# Altium schematic sheet Offset_Selector.SchDoc(Offset_selector1)
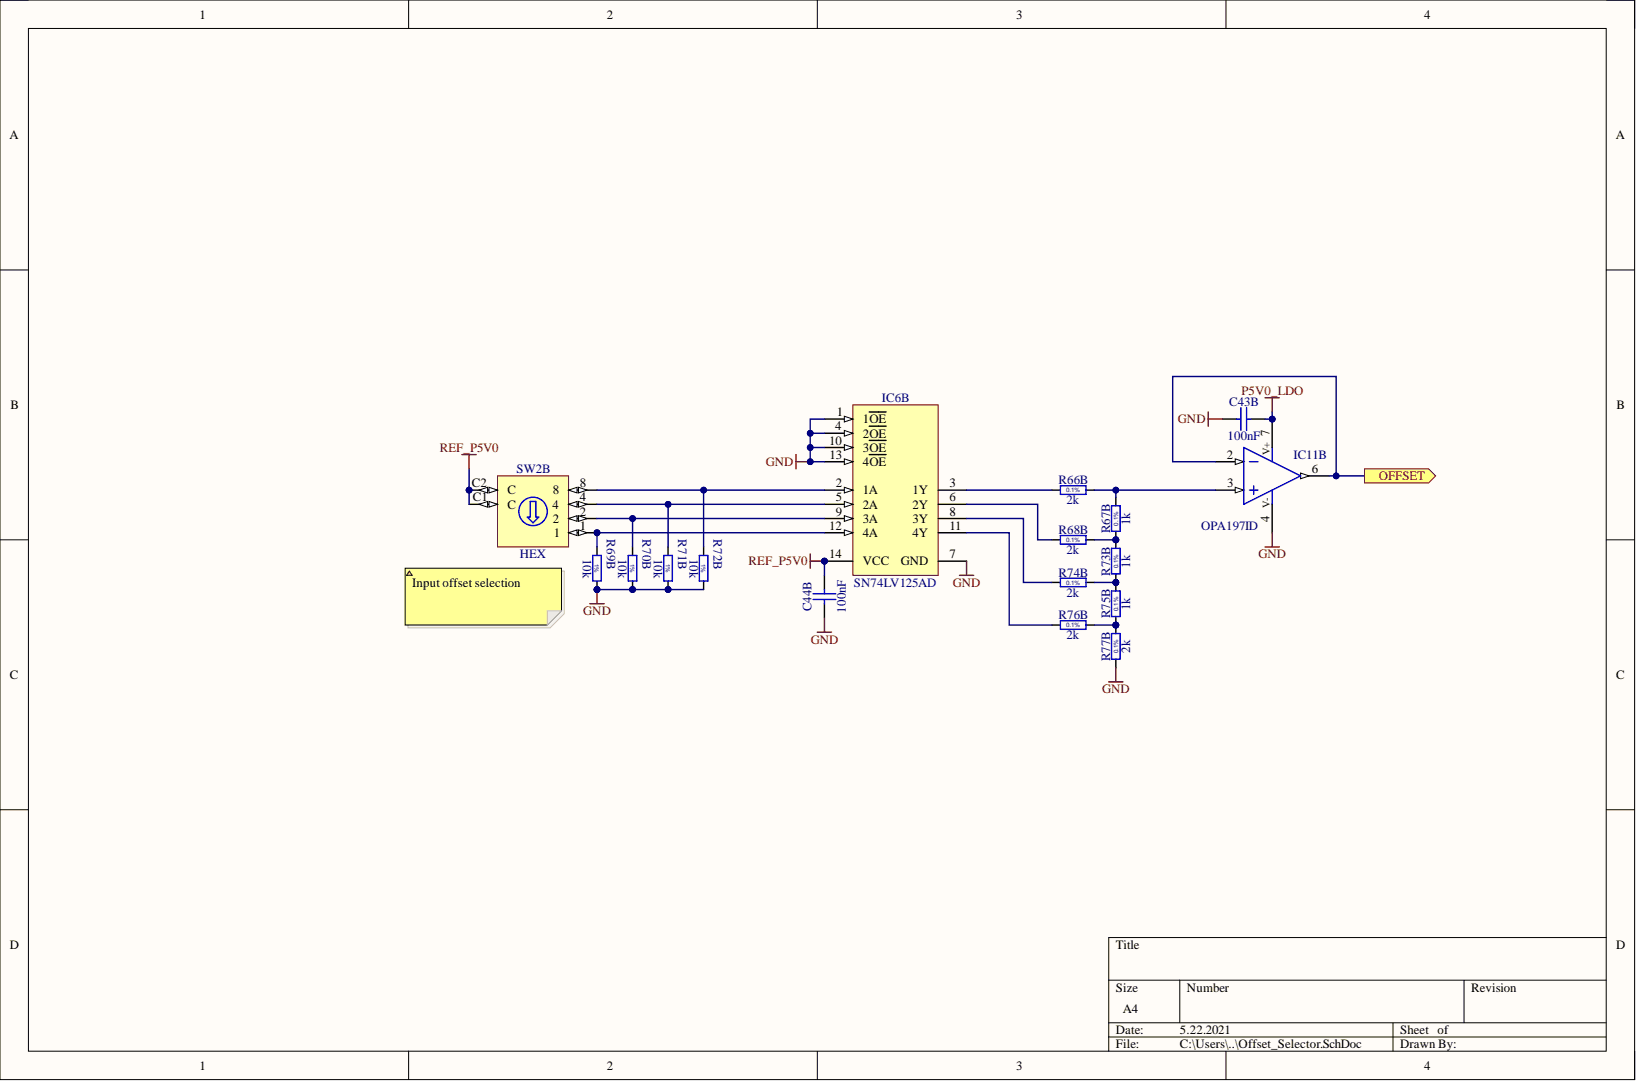
[diagram p0: Offset_Selector.SchDoc]

## Parts
C43B  100nF  [CAPC1608X87N]
C44B  100nF  [CAPC1608X87N]
IC6B  SN74LV125AD  [SOIC127P600X175-14N]
IC11B  OPA197ID  [SOIC127P600X175-8N]
R66B  2k  [RESC1608X55N]
R67B  1k  [RESC1608X55N]
R68B  2k  [RESC1608X55N]
R69B  10k  [RESC1608X55N]
R70B  10k  [RESC1608X55N]
R71B  10k  [RESC1608X55N]
R72B  10k  [RESC1608X55N]
R73B  1k  [RESC1608X55N]
R74B  2k  [RESC1608X55N]
R75B  1k  [RESC1608X55N]
R76B  2k  [RESC1608X55N]
R77B  2k  [RESC1608X55N]
SW2B  HEX  [SW_APEM_PT65106L254]

## Ports
OFFSETB

## Nets
GND: C43B.1 C44B.1 IC6B.1(1OE) IC6B.4(2OE) IC6B.7(GND) IC6B.10(3OE) IC6B.13(4OE) IC11B.4 R69B.2 R70B.2 R71B.2 R72B.2 R77B.1
P5V0_LDO: C43B.2 IC11B.7
REF_P5V0: C44B.2 IC6B.14(VCC) SW2B.C1(C) SW2B.C2(C)
NetIC6B_2: IC6B.2(1A) R72B.1 SW2B.8
NetIC6B_3: IC6B.3(1Y) R66B.1
NetIC6B_5: IC6B.5(2A) R71B.1 SW2B.4
NetIC6B_6: IC6B.6(2Y) R68B.1
NetIC6B_8: IC6B.8(3Y) R74B.1
NetIC6B_9: IC6B.9(3A) R70B.1 SW2B.2
NetIC6B_11: IC6B.11(4Y) R76B.1
NetIC6B_12: IC6B.12(4A) R69B.1 SW2B.1
NetIC11B_2: IC11B.2 IC11B.6
NetIC11B_3: IC11B.3 R66B.2 R67B.2
NetR67B_1: R67B.1 R68B.2 R73B.2
NetR73B_1: R73B.1 R74B.2 R75B.2
NetR75B_1: R75B.1 R76B.2 R77B.2

## Library data of the parts
C43B C44B = CC0603_100NF_50V_10%_X7R: Non-polarised capacitor; Manufacturer: GENERIC; Manufacturer1 Part Number: 223858615649; Part Description: SMD Multilayer Chip Ceramic Capacitor; Database Table Name: Capacitors SMD; TC: X7R; Tolerance: ±10%; Voltage: 50V; Case: 0603; Component Kind: Standard; Component Type: Standard; CreateDate: 03/12/2007; HelpURL: \\cern.ch\dfs\Applications\Altium\Datasheets\CC0603_X7R_PHYCOMP.pdf; LatestRevisionDate: 03/12/2007; Library Name: Capacitors.DbLib; Manufacturer1 ComponentHeight: 0.87mm; Manufacturer1 Example: PHYCOMP; Manufacturer Part Number: CC0603_100NF_50V_10%_X7R; Mounted: Yes; Part Number: CC0603_100NF_50V_10%_X7R; Pin Count: 2; Sense: No; SMD: Yes; Socket: No; Status: Preferred; Value: 100nF; Author: CERN DEM JLC
IC6B = SN74LV125AD: Quadruple Bus Buffer with 3-State Outputs; Manufacturer: TEXAS INSTRUMENTS; Bonding: No; Database Table Name: Standard Logic; PressFit: No; Case: SOIC14; Component Kind: Standard; Component Type: Standard; ComponentHeight: 1.75mm; CreateDate: 09.03.2011; Device: SN74LV125AD; HelpURL: \\cern.ch\dfs\Applications\Altium\Datasheets\SN74LV125AD.pdf; LatestRevisionDate: 09.03.2011; Library Name: ICs And Semiconductors.DbLib; Manufacturer Part Number: SN74LV125AD; Mounted: Yes; PackageDescription: SOIC 14, Pitch 1.27mm - Body 4x8.75mm, IPC Medium Density; Part Description: Quadruple Bus Buffer with 3-State Outputs; Part Number: SN74LV125AD; Pin Count: 14; Sense: No; SMD: Yes; Socket: No; Author: CERN DEM JLC
IC11B = OPA197ID: Operational Amplifier; Manufacturer: TEXAS INSTRUMENTS; Part Description: 36-V, Precision, Rail-to-Rail Input/Output, Low Offset Voltage, Operational Amplifiers; Bonding: No; Database Table Name: Operational Amplifiers; PressFit: No; Case: SOIC8; Component Kind: Standard; Component Type: Standard; ComponentHeight: 1.75mm; CreateDate: 30/06/2017; Device: OPA197ID; HelpURL: \\cern.ch\dfs\Applications\Altium\Datasheets\OPA197ID.pdf; LatestRevisionDate: 30/06/2017; Library Name: ICs And Semiconductors.DbLib; Manufacturer Part Number: OPA197ID; Mounted: Yes; PackageDescription: SOIC 8, Pitch 1.27mm - Body 4x5mm, IPC Medium Density; Part Number: OPA197ID; Pin Count: 8; Sense: No; SMD: Yes; Socket: No; Author: CERN DEM MR
R66B R68B R74B R76B R77B = R0603_2K_0.1%_0.063W_10PPM: Resistor - 0.1%; Manufacturer: GENERIC; Manufacturer1 Part Number: RN73C1J2K0BTDF; Part Description: Thin Film Chip Resistor; Database Table Name: Resistors SMD; Power: 0.063W; PressFit: No; TC: ±10ppm; Case: 0603; Component Kind: Standard; Component Type: Standard; CreateDate: 03.12.2007; HelpURL: \\cern.ch\dfs\Applications\Altium\Datasheets\R0603_Tyco_RN73.pdf; LatestRevisionDate: 13.03.2008; Library Name: Resistors.DbLib; Manufacturer1 ComponentHeight: 0.5mm; Manufacturer1 Example: TYCO HOLSWORTHY; Manufacturer Part Number: R0603_2K_0.1%_0.063W_10PPM; Mounted: Yes; Part Number: R0603_2K_0.1%_0.063W_10PPM; Pin Count: 2; Sense: No; SMD: Yes; Socket: No; Status: Not Recommended; Tolerance: ±0.1%; Value: 2k; Author: CERN DEM JLC
R67B R73B R75B = R0603_1K0_0.1%_0.063W_10PPM: Resistor - 0.1%; Manufacturer: GENERIC; Manufacturer1 Part Number: RN73C1J1K0BTDF; Part Description: Thin Film Chip Resistor; Database Table Name: Resistors SMD; Power: 0.063W; PressFit: No; TC: ±10ppm; Case: 0603; Component Kind: Standard; Component Type: Standard; CreateDate: 03.12.2007; HelpURL: \\cern.ch\dfs\Applications\Altium\Datasheets\R0603_Tyco_RN73.pdf; LatestRevisionDate: 13.03.2008; Library Name: Resistors.DbLib; Manufacturer1 ComponentHeight: 0.5mm; Manufacturer1 Example: TYCO HOLSWORTHY; Manufacturer Part Number: R0603_1K0_0.1%_0.063W_10PPM; Mounted: Yes; Part Number: R0603_1K0_0.1%_0.063W_10PPM; Pin Count: 2; Sense: No; SMD: Yes; Socket: No; Status: Not Recommended; Tolerance: ±0.1%; Value: 1k; Author: CERN DEM JLC
R69B R70B R71B R72B = R0603_10K_1%_0.1W_100PPM: Resistor - 1%; Manufacturer: GENERIC; Manufacturer1 Part Number: NRC06F1002TRF; Part Description: General Purpose Thick Film Chip Resistor; Database Table Name: Resistors SMD; Power: 0.1W; PressFit: No; TC: ±100ppm/°C; Case: 0603; Component Kind: Standard; Component Type: Standard; CreateDate: 03.12.2007; HelpURL: \\cern.ch\dfs\Applications\Altium\Datasheets\R0603_NIC_NRC.pdf; LatestRevisionDate: 13.03.2008; Library Name: Resistors.DbLib; Manufacturer1 ComponentHeight: 0.55mm; Manufacturer1 Example: NIC COMPONENT; Manufacturer Part Number: R0603_10K_1%_0.1W_100PPM; Mounted: Yes; Part Number: R0603_10K_1%_0.1W_100PPM; Pin Count: 2; Sense: No; SMD: Yes; Socket: No; Status: Preferred; Tolerance: ±1%; Value: 10k; Author: CERN DEM JLC
SW2B = SW_APEM_PT65106L254: Rotary Code Switch 1 2 4 8 C1 C2; Manufacturer: APEM; Part Description: Rotary Hexadecimal Right Angled Switch, Screwdriver Actuator, Straight terminals; Database Table Name: Switches; PressFit: No; Component Kind: Standard; Component Type: Standard; ComponentHeight: 10mm; CreateDate: 18.06.2014; Family: HEX; HelpURL: \\cern.ch\dfs\Applications\Altium\Datasheets\SW_APEM_PT65106L254.pdf; LatestRevisionDate: 18.06.2014; Library Name: Eletro-mechanical.DbLib; Manufacturer Part Number: PT65106L254; Mounted: Yes; PackageDescription: Body 10x10mm, Right Angled Rotary Hexadecimal Code Switch With Screwdriver Actuator, APEM PT65 (CR65) Series; Part Number: SW_APEM_PT65106L254; Pin Count: 6; Sense: No; SMD: No; Socket: No; Status: None; Author: CERN DEM JLC
